# Altium schematic sheet PCM_V1.0.SchDoc
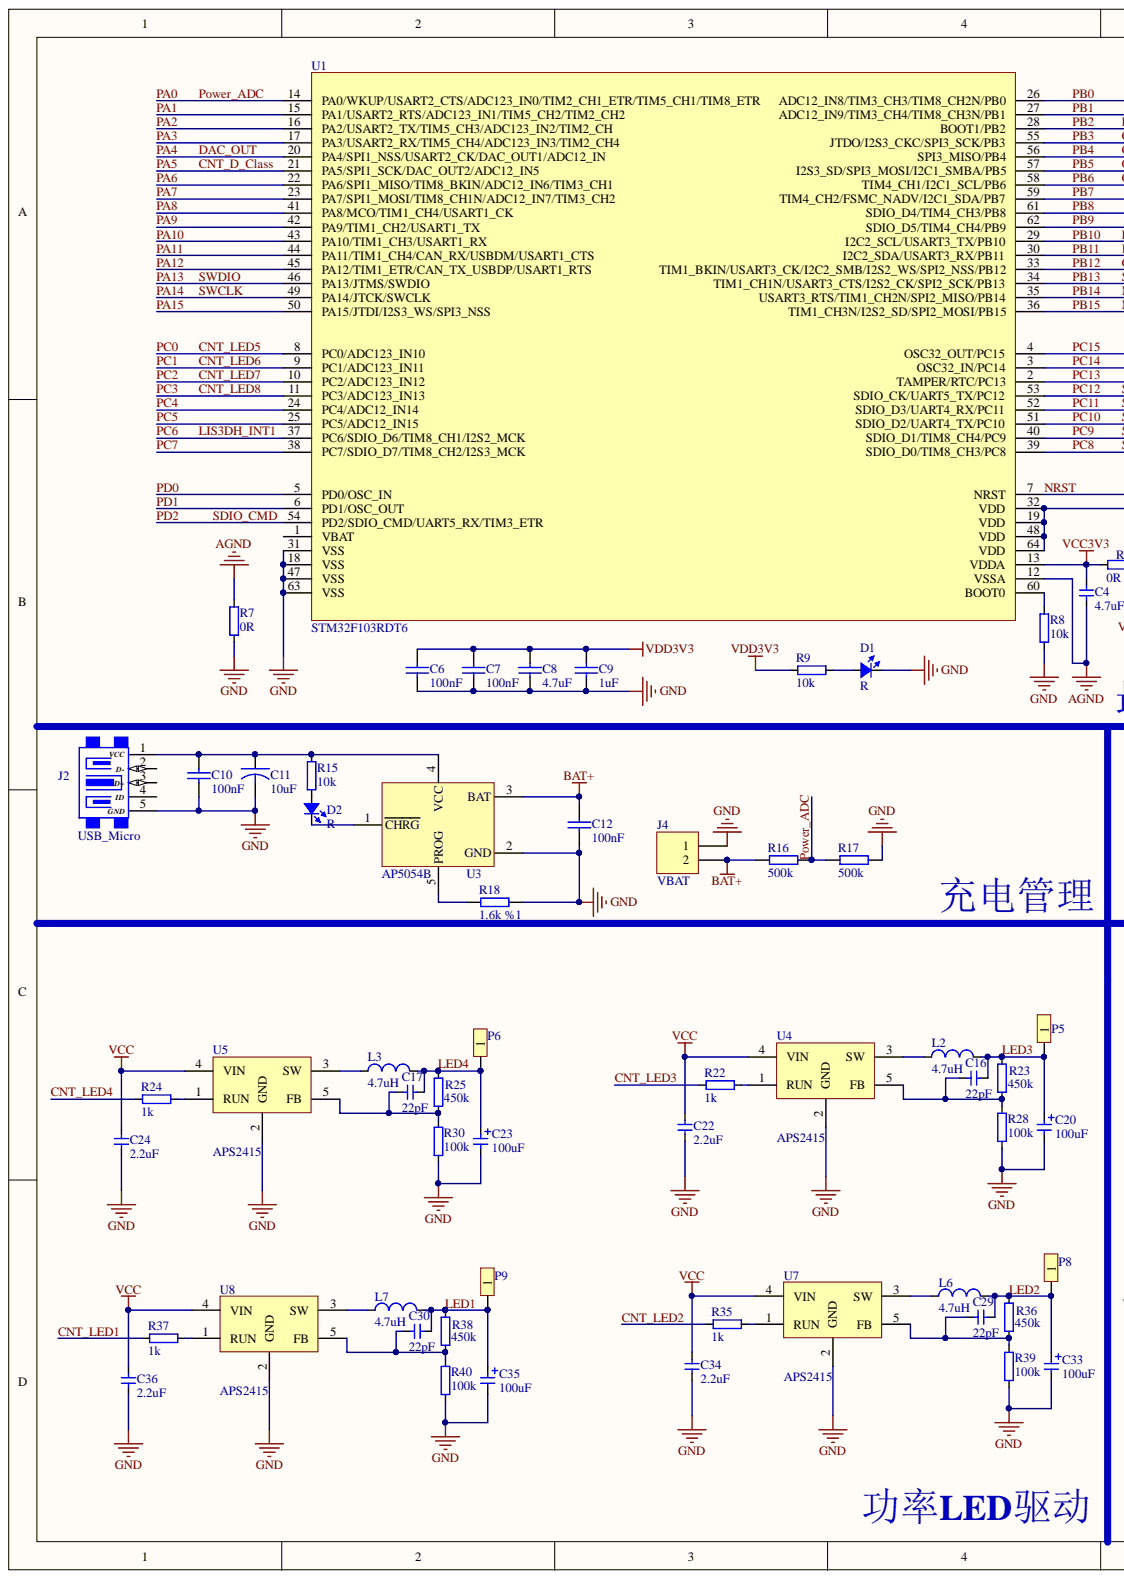
[diagram p0: PCM_V1.0.SchDoc, left]
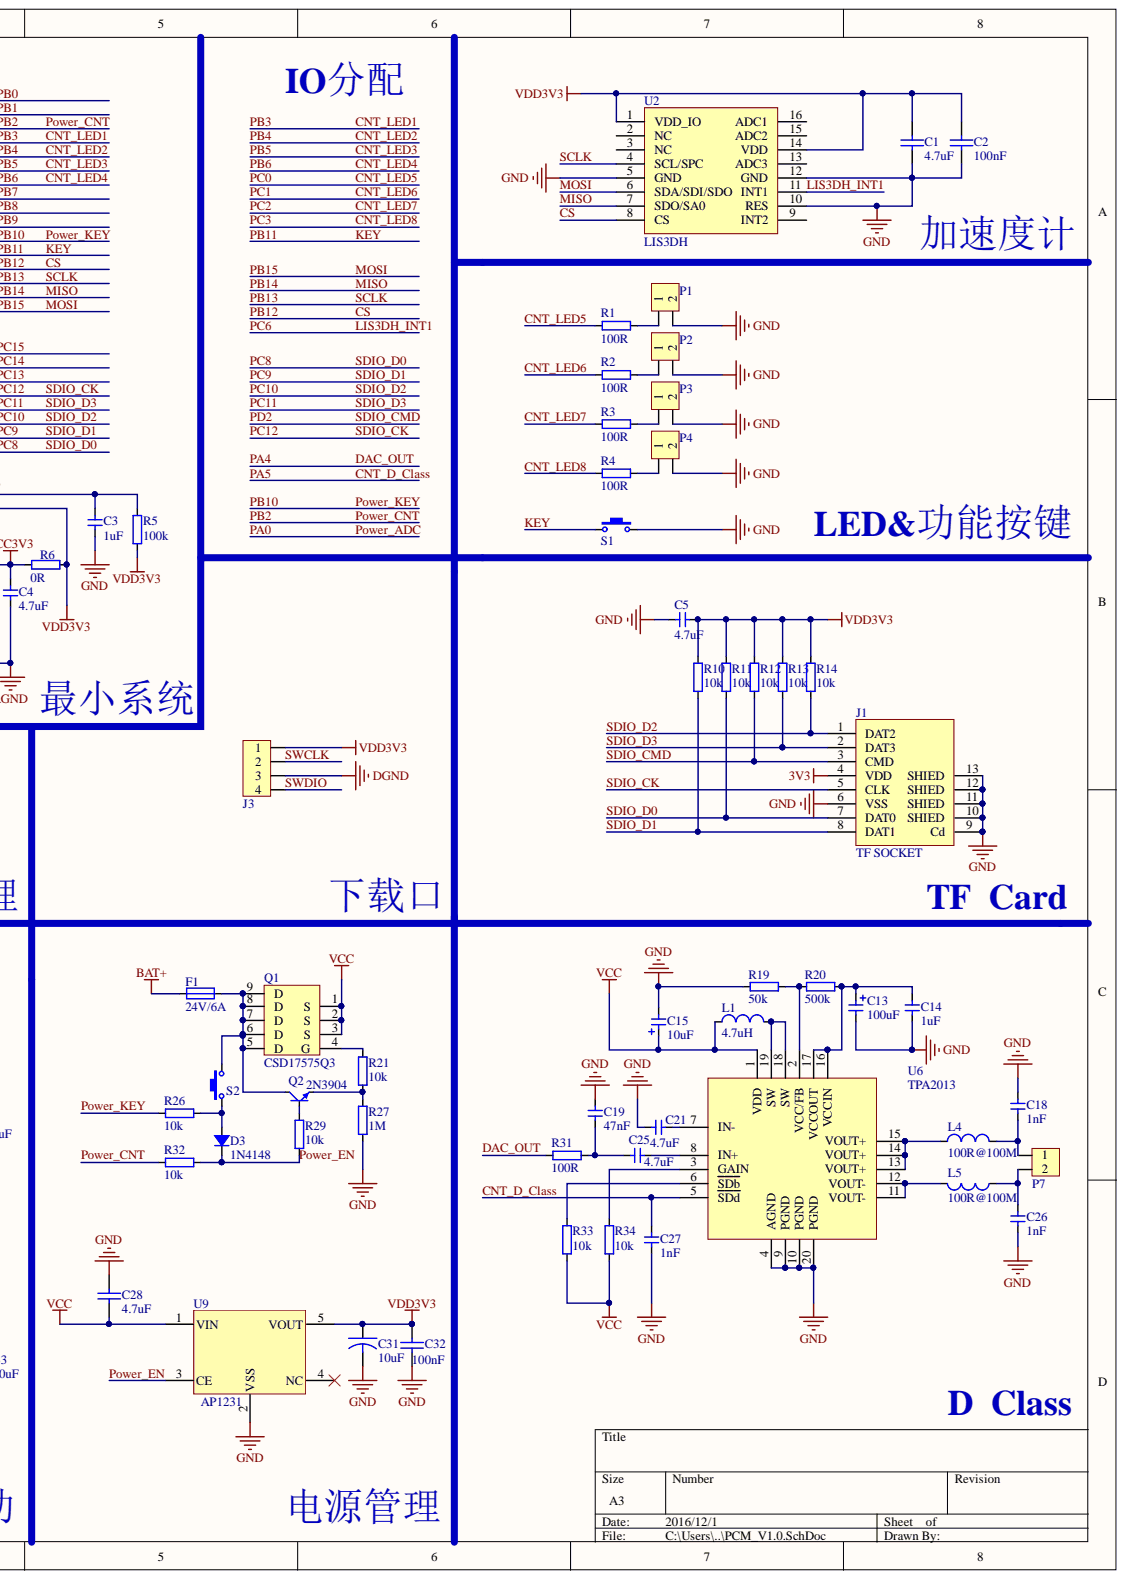
[diagram p1: PCM_V1.0.SchDoc, right]

## Parts
C1  4.7uF  [Cap_0402]
C2  100nF  [Cap_0402]
C3  value 1uF  [CAP_0402]  (hidden comment: Cap_0603)
C4  value 4.7uF  [CAP_0402]  (hidden comment: Cap_0402)
C5  value 4.7uF  [CAP_0402]  (hidden comment: Cap_0402)
C6  100nF  [CAP_0402]
C7  100nF  [CAP_0402]
C8  4.7uF  [CAP_0402]
C9  1uF  [CAP_0402]
C10  100nF  [CAP_0402]
C11  10uF  [cap_0805]
C12  100nF  [CAP_0402]
C13  value 100uF  [CAP_TAN_B1210]  (hidden comment: Cap_Tan_1210)
C14  value 1uF  [CAP_0402]  (hidden comment: Cap_0402)
C15  value 10uF  [CAP_TAN_A1206]  (hidden comment: Cap_Tan_1206)
C16  value 22pF  [CAP_0402]  (hidden comment: Cap_0402)
C17  value 22pF  [CAP_0402]  (hidden comment: Cap_0402)
C18  value 1nF  [CAP_0603]  (hidden comment: Cap_0603)
C19  value 47nF  [CAP_0402]  (hidden comment: Cap_0402)
C20  value 100uF  [CAP_TAN_B1210]  (hidden comment: Cap_Tan_1210)
C21  value 4.7uF  [CAP_0402]  (hidden comment: Cap_0402)
C22  value 2.2uF  [CAP_0402]  (hidden comment: Cap_0402)
C23  value 100uF  [CAP_TAN_B1210]  (hidden comment: Cap_Tan_1210)
C24  value 2.2uF  [CAP_0402]  (hidden comment: Cap_0402)
C25  value 4.7uF  [CAP_0402]  (hidden comment: Cap_0402)
C26  value 1nF  [CAP_0603]  (hidden comment: Cap_0603)
C27  value 1nF  [CAP_0402]  (hidden comment: Cap_0402)
C28  4.7uF  [CAP_0402]
C29  value 22pF  [CAP_0402]  (hidden comment: Cap_0402)
C30  value 22pF  [CAP_0402]  (hidden comment: Cap_0402)
C31  10uF  [CAP_TAN_B1210]
C32  100nF  [CAP_0402]
C33  value 100uF  [CAP_TAN_B1210]  (hidden comment: Cap_Tan_1210)
C34  value 2.2uF  [CAP_0402]  (hidden comment: Cap_0402)
C35  value 100uF  [CAP_TAN_B1210]  (hidden comment: Cap_Tan_1210)
C36  value 2.2uF  [CAP_0402]  (hidden comment: Cap_0402)
D1  Color: R  [LED_0402_RED]  (hidden comment: LED_0402)
D2  Color: R  [LED_0402_RED]  (hidden comment: LED_0402)
D3  value 1N4148  [SOD-123]  (hidden comment: Diode_SMA)
F1  value 24V/6A  [FUSE_1206]  (hidden comment: Fuse_1206)
J1  TF SOCKET  [SD_TF]
J2  USB_Micro  [USB_Micro-AB]
J3  XH4_2.54  [XH4_2.54_180]  (value not drawn)
J4  VBAT  [sip2]
L1  value 4.7uH  [IND_CD43]  (hidden comment: Ind_CD43)
L2  value 4.7uH  [IND_CD43]  (hidden comment: Ind_CD43)
L3  value 4.7uH  [IND_CD43]  (hidden comment: Ind_CD43)
L4  value 100R@100M  [IND_0805]  (hidden comment: Ind_0805)
L5  value 100R@100M  [IND_0805]  (hidden comment: Ind_0805)
L6  value 4.7uH  [IND_CD43]  (hidden comment: Ind_CD43)
L7  value 4.7uH  [IND_CD43]  (hidden comment: Ind_CD43)
P1  HDR1x2_1.27  [HDR1X2_1.27_180]  (value not drawn)
P2  HDR1x2_1.27  [HDR1X2_1.27_180]  (value not drawn)
P3  HDR1x2_1.27  [HDR1X2_1.27_180]  (value not drawn)
P4  HDR1x2_1.27  [HDR1X2_1.27_180]  (value not drawn)
P5  HDR1x1_1.27  [HDR1X1_1.27_180]  (value not drawn)
P6  HDR1x1_1.27  [HDR1X1_1.27_180]  (value not drawn)
P7  HDR1x2_2.54  [HDR1X2_2.54_180]  (value not drawn)
P8  HDR1x1_1.27  [HDR1X1_1.27_180]  (value not drawn)
P9  HDR1x1_1.27  [HDR1X1_1.27_180]  (value not drawn)
Q1  CSD17575Q3  [PSON65P320X100_HS-9N]
Q2  value 2N3904  [SOT23-3]  (hidden comment: BJT_NPN)
R1  value 100R  [RES_0402]  (hidden comment: Res_0402)
R2  value 100R  [RES_0402]  (hidden comment: Res_0402)
R3  value 100R  [RES_0402]  (hidden comment: Res_0402)
R4  value 100R  [RES_0402]  (hidden comment: Res_0402)
R5  value 100k  [RES_0402]  (hidden comment: Res_0603)
R6  value 0R  [RES_0603]  (hidden comment: Res_0603)
R7  value 0R  [RES_0603]  (hidden comment: Res_0603)
R8  value 10k  [RES_0402]  (hidden comment: Res_0603)
R9  value 10k  [RES_0402]  (hidden comment: Res_0402)
R10  value 10k  [RES_0402]  (hidden comment: Res_0603)
R11  value 10k  [RES_0402]  (hidden comment: Res_0603)
R12  value 10k  [RES_0402]  (hidden comment: Res_0603)
R13  value 10k  [RES_0402]  (hidden comment: Res_0603)
R14  value 10k  [RES_0402]  (hidden comment: Res_0603)
R15  value 10k  [RES_0402]  (hidden comment: Res_0402)
R16  500k  [RES_0402]
R17  500k  [RES_0402]
R18  1.6k %1  [RES_0402]
R19  value 50k  [RES_0402]  (hidden comment: Res_0402)
R20  value 500k  [RES_0402]  (hidden comment: Res_0402)
R21  value 10k  [RES_0402]  (hidden comment: Res_0402)
R22  value 1k  [RES_0402]  (hidden comment: Res_0402)
R23  value 450k  [RES_0402]  (hidden comment: Res_0402)
R24  value 1k  [RES_0402]  (hidden comment: Res_0402)
R25  value 450k  [RES_0402]  (hidden comment: Res_0402)
R26  value 10k  [RES_0402]  (hidden comment: Res_0402)
R27  value 1M  [RES_0402]  (hidden comment: Res_0402)
R28  value 100k  [RES_0402]  (hidden comment: Res_0402)
R29  value 10k  [RES_0402]  (hidden comment: Res_0402)
R30  value 100k  [RES_0402]  (hidden comment: Res_0402)
R31  value 100R  [RES_0402]  (hidden comment: Res_0402)
R32  value 10k  [RES_0402]  (hidden comment: Res_0402)
R33  value 10k  [RES_0402]  (hidden comment: Res_0402)
R34  value 10k  [RES_0402]  (hidden comment: Res_0402)
R35  value 1k  [RES_0402]  (hidden comment: Res_0402)
R36  value 450k  [RES_0402]  (hidden comment: Res_0402)
R37  value 1k  [RES_0402]  (hidden comment: Res_0402)
R38  value 450k  [RES_0402]  (hidden comment: Res_0402)
R39  value 100k  [RES_0402]  (hidden comment: Res_0402)
R40  value 100k  [RES_0402]  (hidden comment: Res_0402)
S1  SW-PB_3X4X2  [SW-PB_3X4X2]  (value not drawn)
S2  SW-PB_3X4X2  [SW-PB_3X4X2]  (value not drawn)
U1  STM32F103RDT6  [LQFP-64_10X10XP0.5MM]
U2  LIS3DH  [HCLGA-16_3x3MM]
U3  AP5054B  [SOT95P280X110-5N]
U4  APS2415  [SOT23-5]
U5  APS2415  [SOT23-5]
U6  TPA2013  [QFN_4*4_0.5_20N]
U7  APS2415  [SOT23-5]
U8  APS2415  [SOT23-5]
U9  AP1231  [SOT95P280X110-5N]

## Nets
3V3: J1.4(VDD)
AGND: C4.1 R7.2 U1.12(VSSA)
BAT+: C12.2 F1.1 J4.2 R16.2 U3.3(BAT)
DGND: J3.3
GND: C1.2 C2.2 C3.2 C5.1 C6.1 C7.1 C8.1 C9.1 C10.1 C11.2 C12.1 C13.2 C14.1 C15.2 C18.2 C19.2 C20.2 C21.1 C22.2 C23.2 C24.2 C26.1 C27.1 C28.2 C31.2 C32.1 C33.2 C34.2 C35.2 C36.2 D1.2 J1.6(VSS) J1.9(Cd) J1.10(SHIED) J1.11(SHIED) J1.12(SHIED) J1.13(SHIED) J2.5(GND) J4.1 P1.2 P2.2 P3.2 P4.2 R7.1 R8.2 R17.1 R18.1 R19.1 R27.1 R28.2 R30.2 R39.2 R40.2 S1.2 U1.18(VSS) U1.31(VSS) U1.47(VSS) U1.63(VSS) U2.5(GND) U2.10(RES) U2.12(GND) U3.2(GND) U4.2(GND) U5.2(GND) U6.4(AGND) U6.9(PGND) U6.10(PGND) U6.20(PGND) U7.2(GND) U8.2(GND) U9.2(VSS)
VCC: C15.1 C22.1 C24.1 C28.1 C34.1 C36.1 L1.1 Q1.1(S) Q1.2(S) Q1.3(S) R33.1 R34.1 U4.4(VIN) U5.4(VIN) U6.1(VDD) U7.4(VIN) U8.4(VIN) U9.1(VIN)
VCC3V3: C4.2 R6.1 U1.13(VDDA)
VDD3V3: C1.1 C2.1 C5.2 C6.2 C7.2 C8.2 C9.2 C31.1 C32.2 J3.1 R5.1 R6.2 R9.1 R10.2 R11.2 R12.2 R13.2 R14.2 U1.19(VDD) U1.32(VDD) U1.48(VDD) U1.64(VDD) U2.1(VDD_IO) U2.14(VDD) U9.5(VOUT)
CNT_D_CLASS: C27.2 U1.21(PA5/SPI1_SCK/DAC_OUT2/ADC12_IN5) U6.5(SDd)
CNT_LED1: R37.1 U1.55(JTDO/I2S3_CKC/SPI3_SCK/PB3)
CNT_LED2: R35.1 U1.56(SPI3_MISO/PB4)
CNT_LED3: R22.1 U1.57(I2S3_SD/SPI3_MOSI/I2C1_SMBA/PB5)
CNT_LED4: R24.1 U1.58(TIM4_CH1/I2C1_SCL/PB6)
CNT_LED5: R1.1 U1.8(PC0/ADC123_IN10)
CNT_LED6: R2.1 U1.9(PC1/ADC123_IN11)
CNT_LED7: R3.1 U1.10(PC2/ADC123_IN12)
CNT_LED8: R4.1 U1.11(PC3/ADC123_IN13)
CS: U1.33(TIM1_BKIN/USART3_CK/I2C2_SMB/I2S2_WS/SPI2_NSS/PB12) U2.8(CS)
DAC_OUT: R31.2 U1.20(PA4/SPI1_NSS/USART2_CK/DAC_OUT1/ADC12_IN)
KEY: S1.1 U1.30(I2C2_SDA/USART3_RX/PB11)
LED1: C30.2 C35.1 L7.2 P9.1 R38.1
LED2: C29.2 C33.1 L6.2 P8.1 R36.1
LED3: C16.2 C20.1 L2.2 P5.1 R23.1
LED4: C17.2 C23.1 L3.2 P6.1 R25.1
LIS3DH_INT1: U1.37(PC6/SDIO_D6/TIM8_CH1/I2S2_MCK) U2.11(INT1)
MISO: U1.35(USART3_RTS/TIM1_CH2N/SPI2_MISO/PB14) U2.7(SDO/SA0)
MOSI: U1.36(TIM1_CH3N/I2S2_SD/SPI2_MOSI/PB15) U2.6(SDA/SDI/SDO)
NRST: C3.1 R5.2 U1.7(NRST)
PA0: R16.1 R17.2 U1.14(PA0/WKUP/USART2_CTS/ADC123_IN0/TIM2_CH1_ETR/TIM5_CH1/TIM8_ETR)
PA1: U1.15(PA1/USART2_RTS/ADC123_IN1/TIM5_CH2/TIM2_CH2)
PA2: U1.16(PA2/USART2_TX/TIM5_CH3/ADC123_IN2/TIM2_CH)
PA3: U1.17(PA3/USART2_RX/TIM5_CH4/ADC123_IN3/TIM2_CH4)
PA6: U1.22(PA6/SPI1_MISO/TIM8_BKIN/ADC12_IN6/TIM3_CH1)
PA7: U1.23(PA7/SPI1_MOSI/TIM8_CH1N/ADC12_IN7/TIM3_CH2)
PA8: U1.41(PA8/MCO/TIM1_CH4/USART1_CK)
PA9: U1.42(PA9/TIM1_CH2/USART1_TX)
PA10: U1.43(PA10/TIM1_CH3/USART1_RX)
PA11: U1.44(PA11/TIM1_CH4/CAN_RX/USBDM/USART1_CTS)
PA12: U1.45(PA12/TIM1_ETR/CAN_TX_USBDP/USART1_RTS)
PA13: J3.4 U1.46(PA13/JTMS/SWDIO)
PA14: J3.2 U1.49(PA14/JTCK/SWCLK)
PA15: U1.50(PA15/JTDI/I2S3_WS/SPI3_NSS)
PB0: U1.26(ADC12_IN8/TIM3_CH3/TIM8_CH2N/PB0)
PB1: U1.27(ADC12_IN9/TIM3_CH4/TIM8_CH3N/PB1)
PB2: R32.1 U1.28(BOOT1/PB2)
PB7: U1.59(TIM4_CH2/FSMC_NADV/I2C1_SDA/PB7)
PB8: U1.61(SDIO_D4/TIM4_CH3/PB8)
PB9: U1.62(SDIO_D5/TIM4_CH4/PB9)
PB10: R26.1 U1.29(I2C2_SCL/USART3_TX/PB10)
PB13: U1.34(TIM1_CH1N/USART3_CTS/I2S2_CK/SPI2_SCK/PB13) U2.4(SCL/SPC)
PC4: U1.24(PC4/ADC12_IN14)
PC5: U1.25(PC5/ADC12_IN15)
PC7: U1.38(PC7/SDIO_D7/TIM8_CH2/I2S3_MCK)
PC8: J1.7(DAT0) R11.1 U1.39(SDIO_D0/TIM8_CH3/PC8)
PC9: J1.8(DAT1) R10.1 U1.40(SDIO_D1/TIM8_CH4/PC9)
PC10: J1.1(DAT2) R14.1 U1.51(SDIO_D2/UART4_TX/PC10)
PC11: J1.2(DAT3) R13.1 U1.52(SDIO_D3/UART4_RX/PC11)
PC12: J1.5(CLK) U1.53(SDIO_CK/UART5_TX/PC12)
PC13: U1.2(TAMPER/RTC/PC13)
PC14: U1.3(OSC32_IN/PC14)
PC15: U1.4(OSC32_OUT/PC15)
PD0: U1.5(PD0/OSC_IN)
PD1: U1.6(PD1/OSC_OUT)
PD2: J1.3(CMD) R12.1 U1.54(PD2/SDIO_CMD/UART5_RX/TIM3_ETR)
POWER_EN: D3.2 R29.1 R32.2 U9.3(CE)
NetC10_2: C10.2 C11.1 J2.1(VCC) R15.2 U3.4(VCC)
NetC13_1: C13.1 C14.2 R20.2 U6.16(VCCIN) U6.17(VCCOUT)
NetC16_1: C16.1 R23.2 R28.1 U4.5(FB)
NetC17_1: C17.1 R25.2 R30.1 U5.5(FB)
NetC18_1: C18.1 L4.2 P7.1
NetC19_1: C19.1 C25.1 R31.1
NetC21_2: C21.2 U6.7(IN-)
NetC25_2: C25.2 U6.8(IN+)
NetC26_2: C26.2 L5.1 P7.2
NetC29_1: C29.1 R36.2 R39.1 U7.5(FB)
NetC30_1: C30.1 R38.2 R40.1 U8.5(FB)
NetD1_1: D1.1 R9.2
NetD2_1: D2.1 R15.1
NetD2_2: D2.2 U3.1(CHRG)
NetD3_1: D3.1 R26.2 S2.1
NetF1_2: F1.2 Q1.5(D) Q1.6(D) Q1.7(D) Q1.8(D) Q1.9(D) Q2.3 S2.2
NetL1_2: L1.2 U6.18(SW) U6.19(SW)
NetL2_1: L2.1 U4.3(SW)
NetL3_1: L3.1 U5.3(SW)
NetL4_1: L4.1 U6.13(VOUT+) U6.14(VOUT+) U6.15(VOUT+)
NetL5_2: L5.2 U6.11(VOUT-) U6.12(VOUT-)
NetL6_1: L6.1 U7.3(SW)
NetL7_1: L7.1 U8.3(SW)
NetP1_1: P1.1 R1.2
NetP2_1: P2.1 R2.2
NetP3_1: P3.1 R3.2
NetP4_1: P4.1 R4.2
NetQ1_4: Q1.4(G) R21.2
NetQ2_1: Q2.1 R29.2
NetQ2_2: Q2.2 R21.1 R27.2
NetR8_1: R8.1 U1.60(BOOT0)
NetR18_2: R18.2 U3.5(PROG)
NetR19_2: R19.2 R20.1 U6.2(VCC/FB)
NetR22_2: R22.2 U4.1(RUN)
NetR24_2: R24.2 U5.1(RUN)
NetR33_2: R33.2 U6.6(SDb)
NetR34_2: R34.2 U6.3(GAIN)
NetR35_2: R35.2 U7.1(RUN)
NetR37_2: R37.2 U8.1(RUN)

Not connected: J2 2(D-) 3(D+) 4(ID); U1 1(VBAT); U2 2(NC) 3(NC) 9(INT2) 13(ADC3) 15(ADC2) 16(ADC1); U9 4(NC)

## Library data of the parts
C1 C2 = Cap: Capacitor; Component Kind: Standard; LatestRevisionDate: 17-Jul-2002; LatestRevisionNote: Re-released for DXP Platform.; Library Name: Miscellaneous Devices.IntLib; PackageDescription: Radial Cap, Thru-Hole; 2 Leads; 0.3 in Pin Spacing; PackageReference: RAD-0.3; Published: 8-Jun-2000; Publisher: Altium Limited
  C1: Value: 4.7uF
  C2: Signal Integrity: Cap; Simulation: CAP; Value: 100nF
C3 C18 C26 = Cap_0603: Capacitor; Component Kind: Standard; Library Name: Integrated Library.IntLib
C4 C5 C14 C16 C17 C19 C21 C22 C24 C25 C27 C29 C30 C34 C36 = Cap_0402: Capacitor; Component Kind: Standard; Library Name: Integrated Library.IntLib
C6-C10 C28 = C0603: Component Kind: Standard; Library Name: A_my.SchLib
  C6 C7 C10: Value: 100nF
  C8 C28: Value: 4.7uF
  C9: Value: 1uF
C11 C31 = Cap_Ele: Component Kind: Standard; Library Name: Normal.SchLib
C12 C32 = Cap: Component Kind: Standard; Value: 100nF; Library Name: Normal.SchLib
C13 C20 C23 C33 C35 = Cap_Tan_1210: Capacitor; Component Kind: Standard; Library Name: Integrated Library.IntLib
C15 = Cap_Tan_1206: Capacitor; Component Kind: Standard; Library Name: Integrated Library.IntLib
D1 D2 = LED_0402: LED; Component Kind: Standard; Library Name: Integrated Library.IntLib
D3 = Diode_SMA: Diode; Component Kind: Standard; Library Name: Integrated Library.IntLib
F1 = Fuse_1206: Fuse; Component Kind: Standard; Library Name: Integrated Library.IntLib
J3 = XH4_2.54: Header; Component Kind: Standard; Library Name: Integrated Library.IntLib
J4 = Header 2: Component Kind: Standard; Value: VBAT; Library Name: Normal.SchLib
L1-L3 L6 L7 = Ind_CD43: Inductor; Component Kind: Standard; Library Name: Integrated Library.IntLib
L4 L5 = Ind_0805: Inductor; Component Kind: Standard; Library Name: Integrated Library.IntLib
P1-P4 = HDR1x2_1.27: Header; Component Kind: Standard; Library Name: Integrated Library.IntLib
P5 P6 P8 P9 = HDR1x1_1.27: Header; Component Kind: Standard; Library Name: Integrated Library.IntLib
P7 = HDR1x2_2.54: Header; Component Kind: Standard; Library Name: Integrated Library.IntLib
Q1 = CSD17575Q3: N-MOS; Component Kind: Standard; Library Name: Integrated Library.IntLib
Q2 = BJT_NPN: Transistor; Component Kind: Standard; Library Name: Integrated Library.IntLib
R1-R4 R9 R15 R19-R40 = Res_0402: Resistor; Component Kind: Standard; Library Name: Integrated Library.IntLib
R5-R8 R10-R14 = Res_0603: Resistor; Component Kind: Standard; Library Name: Integrated Library.IntLib
R16-R18 = Res: Component Kind: Standard; Library Name: Normal.SchLib
  R16 R17: Value: 500k
  R18: Value: 1.6k %1
S1 S2 = SW-PB_3X4X2: Button; Component Kind: Standard; Library Name: Integrated Library.IntLib
U3 = AP5054: Component Kind: Standard; Library Name: Chip.SchLib; Value: AP5054B
U6 = TPA2013: D-Class; Component Kind: Standard; Library Name: Integrated Library.IntLib
J1 = TF SOCKET: Component Kind: Standard; Library Name: chtai1.IntLib
J2 = USB_Micro: Component Kind: Standard; Value: USB_Micro; Library Name: Normal.SchLib
U1 = STM32F103RDT6: Component Kind: Standard; Library Name: Integrated Library.IntLib; Value: STM32F103RDT6
U2 = LIS3DH: Component Kind: Standard; Library Name: Integrated Library.IntLib; Value: LIS3DH
U4 U5 U7 U8 = APS2415: Component Kind: Standard; Library Name: Integrated Library.IntLib; Value: APS2415
U9 = AP1231: Component Kind: Standard; Library Name: Chip.SchLib; Value: AP1231
